# Altium schematic sheet violent fan-v2.0.SchDoc
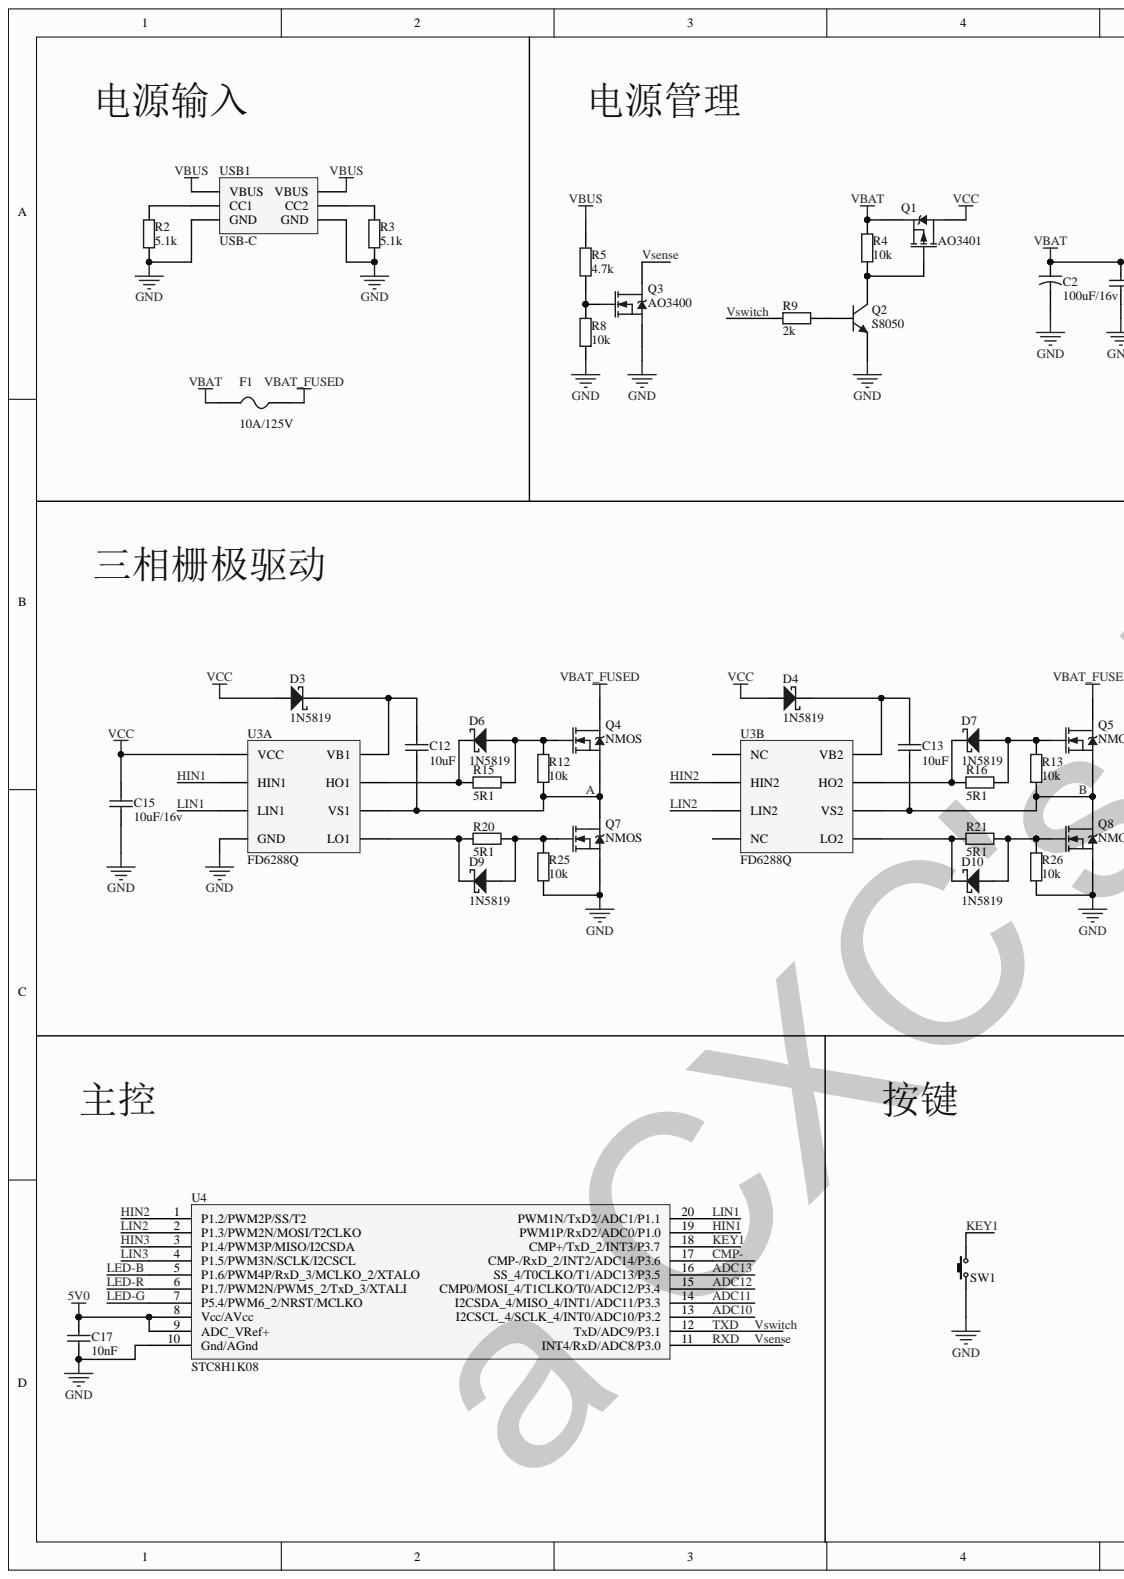
[diagram p0: violent fan-v2.0.SchDoc, left]
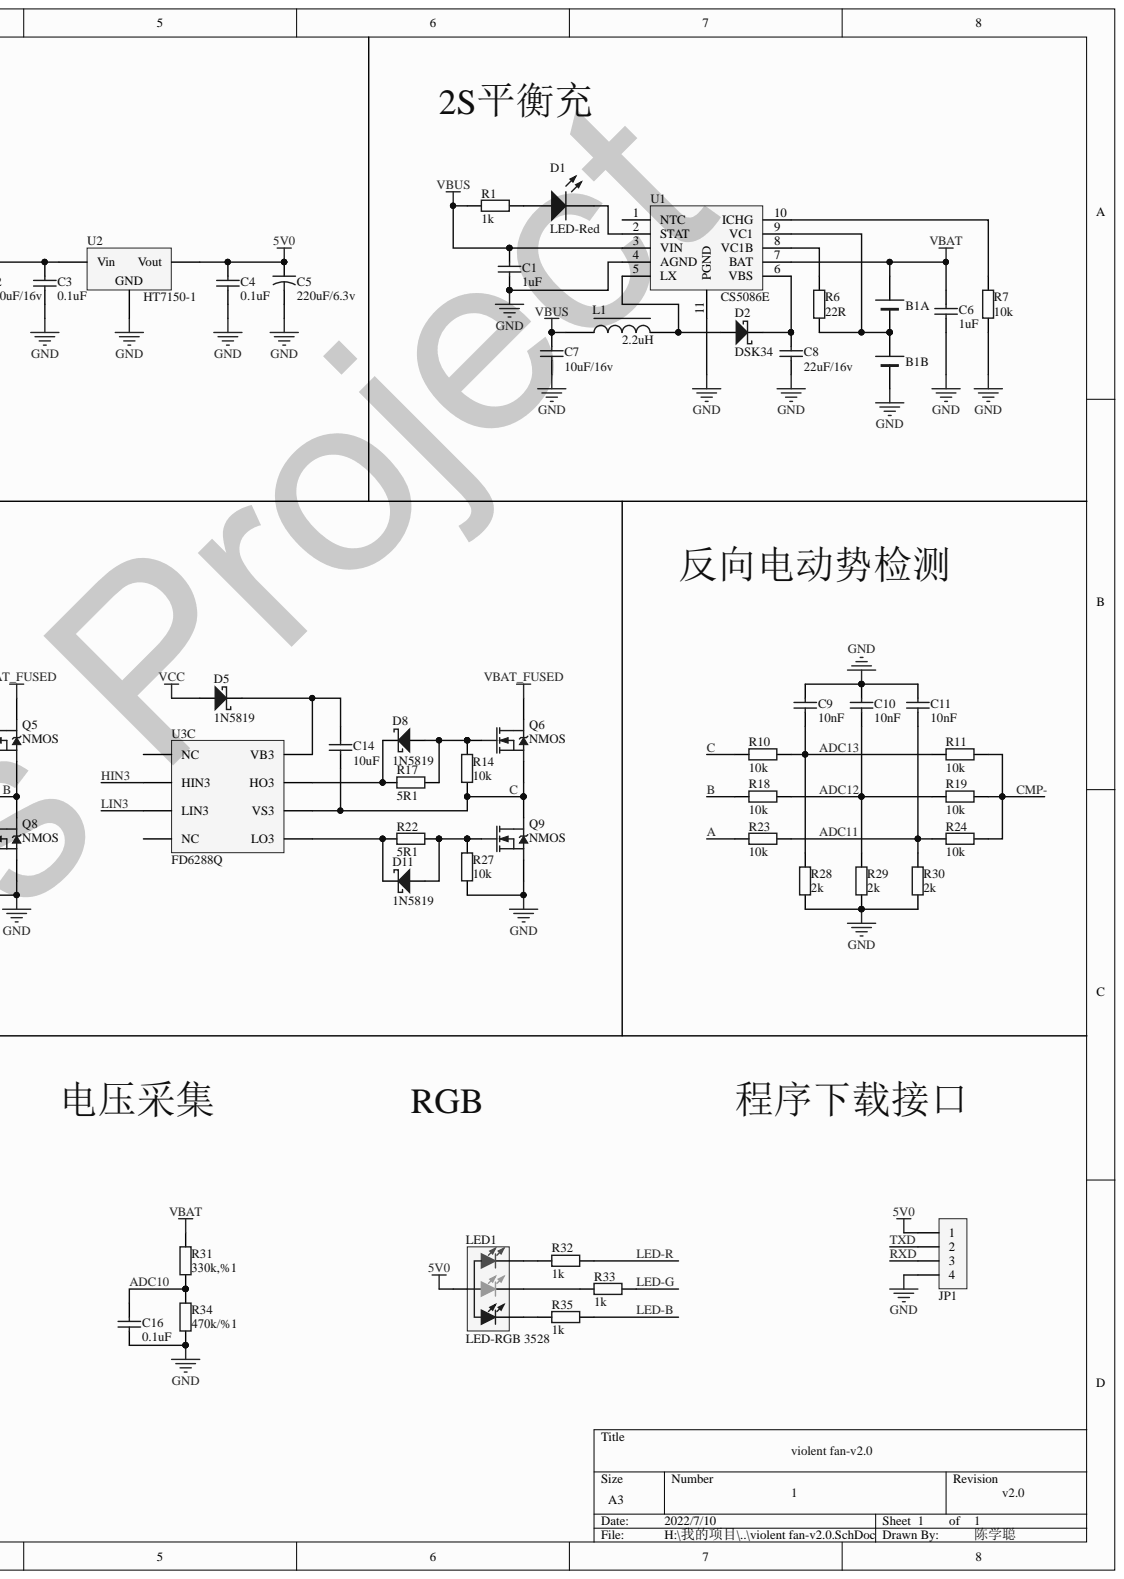
[diagram p1: violent fan-v2.0.SchDoc, right]

## Parts
B1  BATTERY_DUAL  [18650电池座2P-贴片式]  (value not drawn)  (parts A,B)
C1  1uF  [C-0603-M]
C2  100uF/16v  [C-钽电容-6032-N]
C3  0.1uF  [C-0603-M]
C4  0.1uF  [C-0603-M]
C5  220uF/6.3v  [C-钽电容-6032-N]
C6  1uF  [C-0603-M]
C7  10uF/16v  [C-1206-M]
C8  22uF/16v  [C-1206-M]
C9  10nF  [C-0603-M]
C10  10nF  [C-0603-M]
C11  10nF  [C-0603-M]
C12  10uF  [C-0603-M]
C13  10uF  [C-0603-M]
C14  10uF  [C-0603-M]
C15  10uF/16v  [C-1206-M]
C16  0.1uF  [C-0603-M]
C17  10nF  [C-0603-M]
D1  LED-Red  [LED-0603-Red]
D2  DSK34  [SOD-123]
D3  1N5819  [SOD-323]
D4  1N5819  [SOD-323]
D5  1N5819  [SOD-323]
D6  1N5819  [SOD-323]
D7  1N5819  [SOD-323]
D8  1N5819  [SOD-323]
D9  1N5819  [SOD-323]
D10  1N5819  [SOD-323]
D11  1N5819  [SOD-323]
F1  10A/125V  [FU 1808]
JP1  HDR-1X4  [HDR2.54-LI-4P-SMD]  (value not drawn)
L1  2.2uH  [CD54]
LED1  LED-RGB 3528  [LED 3528-4Pin]
Q1  AO3401  [SOT-23]
Q2  S8050  [SOT-23]
Q3  AO3400  [SOT-23]
Q4  NMOS  [DFN5x6_8L_EP1_P]
Q5  NMOS  [DFN5x6_8L_EP1_P]
Q6  NMOS  [DFN5x6_8L_EP1_P]
Q7  NMOS  [DFN5x6_8L_EP1_P]
Q8  NMOS  [DFN5x6_8L_EP1_P]
Q9  NMOS  [DFN5x6_8L_EP1_P]
R1  1k  [R-0603-M]
R2  5.1k  [R-0603-M]
R3  5.1k  [R-0603-M]
R4  10k  [R-0603-M]
R5  4.7k  [R-0603-M]
R6  22R  [R-0603-M]
R7  10k  [R-0603-M]
R8  10k  [R-0603-M]
R9  2k  [R-0603-M]
R10  10k  [R-0603-M]
R11  10k  [R-0603-M]
R12  10k  [R-0603-M]
R13  10k  [R-0603-M]
R14  10k  [R-0603-M]
R15  5R1  [R-0603-M]
R16  5R1  [R-0603-M]
R17  5R1  [R-0603-M]
R18  10k  [R-0603-M]
R19  10k  [R-0603-M]
R20  5R1  [R-0603-M]
R21  5R1  [R-0603-M]
R22  5R1  [R-0603-M]
R23  10k  [R-0603-M]
R24  10k  [R-0603-M]
R25  10k  [R-0603-M]
R26  10k  [R-0603-M]
R27  10k  [R-0603-M]
R28  2k  [R-0603-M]
R29  2k  [R-0603-M]
R30  2k  [R-0603-M]
R31  470k,%1  [R-0603-M]
R32  1k  [R-0603-M]
R33  1k  [R-0603-M]
R34  330k/%1  [R-0603-M]
R35  1k  [R-0603-M]
SW1  Button  [TSW SMD-6*6*6]  (value not drawn)
U1  CS5086E  [ESOP10L]
U2  HT7150-1  [SOT-89]
U3  FD6288Q  [QFN24 4x4]  (parts A,B,C)
U4  STC8H1K08  [TSSOP20_L]
USB1  USB-C  [USB-C-SMD_10P]

## Nets
5V0: C4.1 C5.1 C17.1 JP1.1 LED1.4 U2.3 U4.8 U4.9
GND: B1.4 C1.2 C2.2 C3.2 C4.2 C5.2 C6.2 C7.2 C8.2 C9.1 C10.1 C11.1 C15.2 C16.2 C17.2 JP1.4 Q2.2 Q3.2 Q7.2 Q8.2 Q9.2 R2.1 R3.1 R7.1 R8.2 R25.1 R26.1 R27.1 R28.2 R29.2 R30.2 R34.1 SW1.1 U1.4 U1.11 U2.1 U3.6 U4.10 USB1.A12 USB1.B12
VBAT: B1.1 C2.1 C3.1 C6.1 F1.1 Q1.2 R4.1 R31.2 U1.7 U2.2
VBAT_FUSED: F1.2 Q4.3 Q5.3 Q6.3
VBUS: C1.1 C7.1 L1.1 R1.2 R5.1 U1.3 USB1.A9 USB1.B9
VCC: C15.1 D3.1 D4.1 D5.1 Q1.3 U3.4
A: C12.2 Q4.2 Q7.3 R12.1 R23.2 U3.18
ADC10: C16.1 R31.1 R34.2 U4.13
ADC11: C11.2 R23.1 R24.2 R30.1 U4.14
ADC12: C10.2 R18.1 R19.2 R29.1 U4.15
ADC13: C9.2 R10.1 R11.2 R28.1 U4.16
B: C13.2 Q5.2 Q8.3 R13.1 R18.2 U3.15
C: C14.2 Q6.2 Q9.3 R10.2 R14.1 U3.12
CMP-: R11.1 R19.1 R24.1 U4.17
HIN1: U3.22 U4.19
HIN2: U3.23 U4.1
HIN3: U3.24 U4.3
KEY1: SW1.2 U4.18
LED-B: R35.1 U4.5
LED-G: R33.1 U4.7
LED-R: R32.1 U4.6
LIN1: U3.1 U4.20
LIN2: U3.2 U4.2
LIN3: U3.3 U4.4
RXD: JP1.3 Q3.3 U4.11
TXD: JP1.2 R9.1 U4.12
NetB1_2: B1.2 B1.3 R6.1 U1.9
NetC8_1: C8.1 D2.2 U1.6
NetC12_1: C12.1 D3.2 U3.20
NetC13_1: C13.1 D4.2 U3.17
NetC14_1: C14.1 D5.2 U3.14
NetD1_1: D1.1 R1.1
NetD1_2: D1.2 U1.2
NetD2_1: D2.1 L1.2 U1.5
NetD6_1: D6.1 Q4.1 R12.2 R15.2
NetD6_2: D6.2 R15.1 U3.19
NetD7_1: D7.1 Q5.1 R13.2 R16.2
NetD7_2: D7.2 R16.1 U3.16
NetD8_1: D8.1 Q6.1 R14.2 R17.2
NetD8_2: D8.2 R17.1 U3.13
NetD9_1: D9.1 Q7.1 R20.2 R25.2
NetD9_2: D9.2 R20.1 U3.11
NetD10_1: D10.1 Q8.1 R21.2 R26.2
NetD10_2: D10.2 R21.1 U3.10
NetD11_1: D11.1 Q9.1 R22.2 R27.2
NetD11_2: D11.2 R22.1 U3.9
NetLED1_1: LED1.1 R32.2
NetLED1_2: LED1.2 R33.2
NetLED1_3: LED1.3 R35.2
NetQ1_1: Q1.1 Q2.3 R4.2
NetQ2_1: Q2.1 R9.2
NetQ3_1: Q3.1 R5.2 R8.1
NetR2_2: R2.2 USB1.A5
NetR3_2: R3.2 USB1.B5
NetR6_2: R6.2 U1.8
NetR7_2: R7.2 U1.10

Not connected: U1 1; U3 5 7 8 21

## Library data of the parts
B1 = BATTERY_DUAL: 电池座、电池位; Component Kind: Standard; Library Name: cxc’s Integrated_Library.IntLib
C1 C6-C15 C17 = Cap: 无极性电容; Value: 0.1uF; Component Kind: Standard; LatestRevisionDate: 17-Jul-2002; LatestRevisionNote: Re-released for DXP Platform.; PackageDescription: Radial Cap, Thru-Hole; 2 Leads; 0.3 in Pin Spacing; PackageReference: RAD-0.3; Published: 8-Jun-2000; Library Name: cxc’s Integrated_Library.IntLib; Publisher: Altium Limited
C2 C5 = Cap_1: 有极性电容; Value: 10uF; Component Kind: Standard; PackageDescription: Capacitor; 2 Leads; PackageReference: CAPR5-4X5; Published: 23-Sep-2002; Library Name: cxc’s Integrated_Library.IntLib; Publisher: Altium Limited
C3 C4 C16 = Cap: 无极性电容; Component Kind: Standard; LatestRevisionDate: 17-Jul-2002; LatestRevisionNote: Re-released for DXP Platform.; PackageDescription: Radial Cap, Thru-Hole; 2 Leads; 0.3 in Pin Spacing; PackageReference: RAD-0.3; Published: 8-Jun-2000; Value: 0.1uF; Library Name: cxc’s Integrated_Library.IntLib; Publisher: Altium Limited
D1 = LED: Typical RED, GREEN, YELLOW, AMBER GaAs LED; Component Kind: Standard; LatestRevisionDate: 17-Jul-2002; LatestRevisionNote: Re-released for DXP Platform.; PackageDescription: SM LED; 2 Flat Leads; PackageReference: 3.2X1.6X1.1; PackageVersion: Feb-2002; Published: 8-Jun-2000; Library Name: cxc’s Integrated_Library.IntLib; Publisher: Altium Limited
D2 = DSK34: 3A/40V 贴片肖特基二极管; Component Kind: Standard; Library Name: cxc’s Integrated_Library.IntLib
D3-D11 = 1N5819: 肖特基二极管; Component Kind: Standard; Library Name: cxc’s Integrated_Library.IntLib
F1 = FUSE: 贴片保险丝; 免费技术支持，联系售后: 唯一出售店铺网址https://shop463601444.taobao.com; 封装库来自淘宝卖家  ID：黄晶宝石: 其他店铺购入均为盗版假冒封装库，请立即申请退款，本店3~10元现金奖励; BOM名称: 贴片保险丝; Component Kind: Standard; Library Name: cxc’s Integrated_Library.IntLib
JP1 = Header 4: 4P接插件; 优秀评价可免费更新封装库: 联系淘宝ID：黄晶宝石，获取更新版封装库; 卖家免费技术支持，联系售后: 唯一出售店铺网址https://shop463601444.taobao.com; 封装库来自淘宝卖家 ID：黄晶宝石: 其他店铺购入均为盗版，请立即申请退款; Component Kind: Standard; Library Name: cxc’s Integrated_Library.IntLib
L1 = Inductance2: 电感; Component Kind: Standard; Library Name: cxc’s Integrated_Library.IntLib
LED1 = LED-RGB 3528: 三基色LED封装3528; BOM名称: 三基色LED; 卖家免费查找封装，联系售后: 唯一出售店铺网址https://shop463601444.taobao.com; 封装库来自淘宝卖家 ID：黄晶宝石: 其他店铺购入均为盗版，不享受售后技术支持服务，请立即申请退款; Component Kind: Standard; Library Name: cxc’s Integrated_Library.IntLib
Q1 = PMOS: P沟道场效应管; 版权归属: 源创客; BOM名称: P沟道场效应管; Component Kind: Standard; 学习资源: www.ooocke.com; Library Name: cxc’s Integrated_Library.IntLib
Q2 = NPN: NPN型三极管; Code_JEDEC: TO-226-AA; Component Kind: Standard; LatestRevisionDate: 17-Jul-2002; LatestRevisionNote: Re-released for DXP Platform.; PackageDescription: TO, Flat Index; 3 In-Line, Axial Leads; Body Dia. 4.8mm; Leads 0.55 x 0.51 mm (max); PackageReference: TO-226-AA; Published: 8-Jun-2000; Library Name: cxc’s Integrated_Library.IntLib; Publisher: Altium Limited
Q3-Q9 = NMOS: N沟道场效应管; 版权归属: 源创客; BOM名称: N沟道场效应管; Component Kind: Standard; 学习资源: www.ooocke.com; Library Name: cxc’s Integrated_Library.IntLib
R1 R32 R33 R35 = Resistor: 电阻; Component Kind: Standard; LatestRevisionDate: 17-Jul-2002; LatestRevisionNote: Re-released for DXP Platform.; PackageDescription: Axial Device, Thru-Hole; 2 Leads; 0.4 in Pin Spacing; PackageReference: AXIAL-0.4; Published: 8-Jun-2000; Value: 1k; Library Name: cxc’s Integrated_Library.IntLib; Publisher: Altium Limited
R2-R31 R34 = Resistor: 电阻; Value: 1k; Component Kind: Standard; LatestRevisionDate: 17-Jul-2002; LatestRevisionNote: Re-released for DXP Platform.; PackageDescription: Axial Device, Thru-Hole; 2 Leads; 0.4 in Pin Spacing; PackageReference: AXIAL-0.4; Published: 8-Jun-2000; Library Name: cxc’s Integrated_Library.IntLib; Publisher: Altium Limited
SW1 = Button: 轻触开关; BOM名称: 3x6轻触开关; 版权归属: 源创客; Component Kind: Standard; 学习资源: www.ooocke.com; Library Name: cxc’s Integrated_Library.IntLib
U1 = CS5086E: 5VUSB输入，最大1.5A充电电流，带NTC及电量均衡功能，双节锂电池升压充电管理电路; Component Kind: Standard; Library Name: cxc's Schlib.SchLib
U2 = HT7150-1: 低压差线性稳压器-5.0V; Component Kind: Standard; LatestRevisionDate: 29-May-2009; LatestRevisionNote: IPC-7351 Footprint Added.; PackageDescription: 3-Pin Transistor Outline Package 2.54 mm Pitch, 15.1 mm Lead Span; PackageReference: D2PAK; Published: 24-Mar-1999; Library Name: cxc’s Integrated_Library.IntLib; Publisher: Altium Limited
U3 = FD6288Q: 三相250V栅极驱动器; Component Kind: Standard; Library Name: cxc’s Integrated_Library.IntLib
U4 = STC8H1K08-TSSOP20: 增强型51单片机; Component Kind: Standard; Library Name: cxc’s Integrated_Library.IntLib
USB1 = USB-C-SMD_10P: USB-C型母接口; 卖家免费查找封装，联系售后: 唯一出售店铺网址https://shop463601444.taobao.com; 封装库来自淘宝卖家 ID：黄晶宝石: 其他店铺购入均为盗版假冒封装库，请立即申请退款，本店3~10元现金奖励; BOM名称: USB-C型母接口; Component Kind: Standard; Library Name: cxc’s Integrated_Library.IntLib
